# Altium schematic sheet [07] USB_HUB.SchDoc(BLK4)
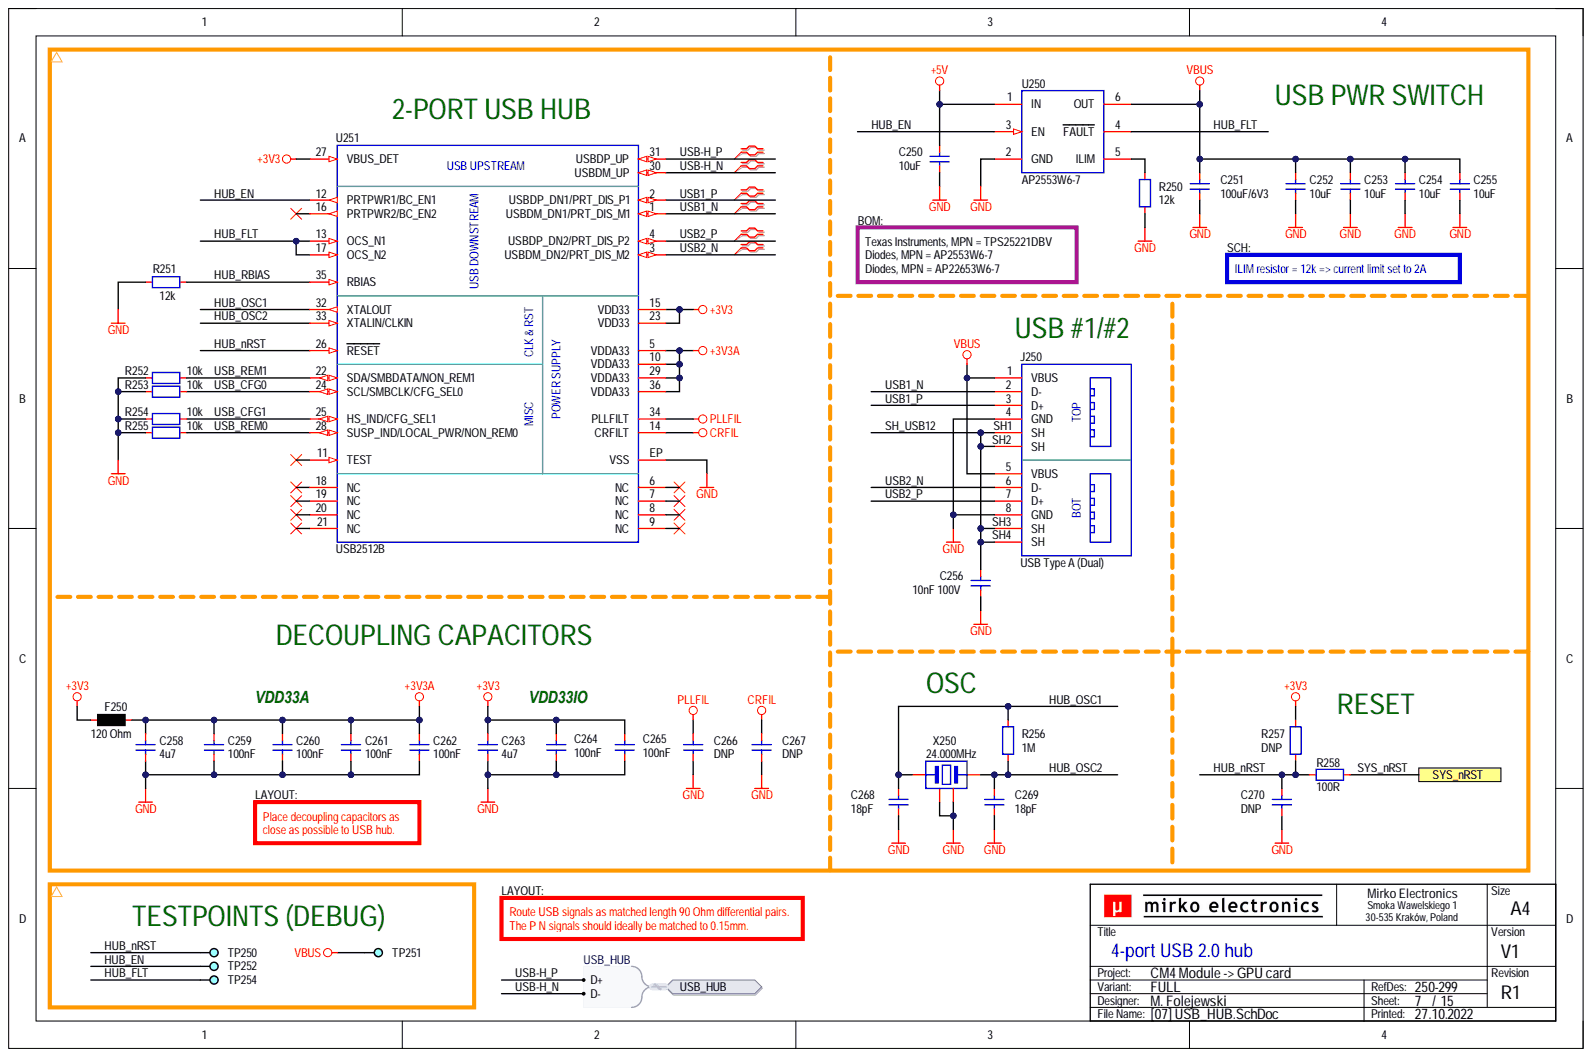
[diagram p0: [07] USB_HUB.SchDoc]

## Parts
C250  10uF  [CAP_0603_R]
C251  100uF/6V3  [CAP_1206_R]
C252  10uF  [CAP_0603_R]
C253  10uF  [CAP_0603_R]
C254  10uF  [CAP_0603_R]
C255  10uF  [CAP_0603_R]
C256  10nF 100V  [CAP_0603_R]
C258  4u7  [CAP_0603_R]
C259  100nF  [CAP_0603_R]
C260  100nF  [CAP_0603_R]
C261  100nF  [CAP_0603_R]
C262  100nF  [CAP_0603_R]
C263  4u7  [CAP_0603_R]
C264  100nF  [CAP_0603_R]
C265  100nF  [CAP_0603_R]
C266  DNP  [CAP_0603_R]
C267  DNP  [CAP_0603_R]
C268  18pF  [CAP_0603_R]
C269  18pF  [CAP_0603_R]
C270  DNP  [CAP_0603_R]
F250  120 Ohm  [FER_0603_R]
J250  USB Type A (Dual)  [CON_USB_TYPE_A_2X]
R250  12k  [RES_0603_R]
R251  12k  [RES_0603_R]
R252  10k  [RES_0603_R]
R253  10k  [RES_0603_R]
R254  10k  [RES_0603_R]
R255  10k  [RES_0603_R]
R256  1M  [RES_0603_R]
R257  DNP  [RES_0603_R]
R258  100R  [RES_0603_R]
TP250  DEBUG  [PCB_TP_DBG_50]  (value not drawn)  (no BOM)
TP251  DEBUG  [PCB_TP_DBG_50]  (no BOM)
TP252  DEBUG  [PCB_TP_DBG_50]  (value not drawn)  (no BOM)
TP254  DEBUG  [PCB_TP_DBG_50]  (value not drawn)  (no BOM)
U250  AP2553W6-7  [PKG_SOT23-6]
U251  USB2512B
X250  24.000MHz  [OSC_3225_4P_R]

## Ports
SYS_nRST, USB_HUB, USB_HUB.D+, USB_HUB.D-

## Nets
+3V3: C263.2 C264.2 C265.2 F250.1 R257.2 U251.15(VDD33) U251.23(VDD33) U251.27(VBUS_DET)
+3V3A: C258.2 C259.2 C260.2 C261.2 C262.2 F250.2 U251.5(VDDA33) U251.10(VDDA33) U251.29(VDDA33) U251.36(VDDA33)
+5V: C250.2 U250.1(IN)
CRFIL: C267.2 U251.14(CRFILT)
GND: C250.1 C251.1 C252.1 C253.1 C254.1 C255.1 C256.1 C258.1 C259.1 C260.1 C261.1 C262.1 C263.1 C264.1 C265.1 C266.1 C267.1 C268.1 C269.1 C270.1 J250.4(GND) J250.8(GND) R250.1 R251.1 R252.1 R253.1 R254.1 R255.1 U250.2(GND) U251.EP(VSS) X250.2 X250.4
PLLFIL: C266.2 U251.34(PLLFILT)
VBUS: C251.2 C252.2 C253.2 C254.2 C255.2 J250.1(VBUS) J250.5(VBUS) TP251.1 U250.6(OUT)
HUB_EN: TP252.1 U250.3(EN) U251.12(PRTPWR1/BC_EN1)
HUB_FLT: TP254.1 U250.4(FAULT) U251.13(OCS_N1) U251.17(OCS_N2)
HUB_nRST: C270.2 R257.1 R258.1 TP250.1 U251.26(RESET)
HUB_OSC1: C268.2 R256.2 U251.32(XTALOUT) X250.1
HUB_OSC2: C269.2 R256.1 U251.33(XTALIN/CLKIN) X250.3
HUB_RBIAS: R251.2 U251.35(RBIAS)
SH_USB12: C256.2 J250.SH1(SH) J250.SH2(SH) J250.SH3(SH) J250.SH4(SH)
SYS_nRST: R258.2 ; port SYS_nRST
USB1_N: J250.2(D-) U251.1(USBDM_DN1/PRT_DIS_M1)
USB1_P: J250.3(D+) U251.2(USBDP_DN1/PRT_DIS_P1)
USB2_N: J250.6(D-) U251.3(USBDM_DN2/PRT_DIS_M2)
USB2_P: J250.7(D+) U251.4(USBDP_DN2/PRT_DIS_P2)
USB-H_N: U251.30(USBDM_UP) ; port USB_HUB
USB-H_P: U251.31(USBDP_UP) ; port USB_HUB
USB_CFG0: R253.2 U251.24(SCL/SMBCLK/CFG_SEL0)
USB_CFG1: R254.2 U251.25(HS_IND/CFG_SEL1)
USB_REM0: R255.2 U251.28(SUSP_IND/LOCAL_PWR/NON_REM0)
USB_REM1: R252.2 U251.22(SDA/SMBDATA/NON_REM1)
NetR250_2: R250.2 U250.5(ILIM)

Not connected: U251 6(NC) 7(NC) 8(NC) 9(NC) 11(TEST) 16(PRTPWR2/BC_EN2) 18(NC) 19(NC) 20(NC) 21(NC)

## Library data of the parts
C250 C252-C256 C258 C263 = CAP-0023: Capacitor; MLCC; GENERIC; TBD; TBD; TBD; TBD; SMD; 0603 (1608);; Database Table Name: CAPACITOR$; Component Kind: Standard; Component Subtype: MLCC; Component Type: Capacitor; Manufacturer: GENERIC; Mounting Type: SMD; MPN: GENERIC; Package (Case): 0603 (1608); Supplier: ?; Library Name: MirFol_db_local.DbLib
  C250 C252-C255: Value: 10uF
  C256: Value: 10nF 100V
  C258 C263: Value: 4u7
C251 = CAP-0025: Capacitor; MLCC; GENERIC; TBD; TBD; TBD; TBD; SMD; 1206 (3216);; Database Table Name: CAPACITOR$; Component Kind: Standard; Component Subtype: MLCC; Component Type: Capacitor; Manufacturer: GENERIC; Mounting Type: SMD; MPN: GENERIC; Package (Case): 1206 (3216); Supplier: ?; Value: 100uF/6V3; Library Name: MirFol_db_local.DbLib
C259-C262 C264 C265 = CAP-0008: Capacitor; MLCC; 100nF; TBD; TBD; TBD; TBD; SMD; 0603 (1608);; Database Table Name: CAPACITOR$; Component Kind: Standard; Component Subtype: MLCC; Component Type: Capacitor; Manufacturer: TBD; Mounting Type: SMD; MPN: TBD; Package (Case): 0603 (1608); Supplier: ?; Value: 100nF; Library Name: MirFol_db_local.DbLib
C266 C267 C270 = CAP-0018: Capacitor; MLCC; DNP; TBD; TBD; TBD; DO NOT PLACE; SMD; 0603 (1608);; Database Table Name: CAPACITOR$; Component Kind: Standard; Component Subtype: MLCC; Component Type: Capacitor; Manufacturer: DNP; Mounting Type: SMD; MPN: DNP; Package (Case): 0603 (1608); Supplier: ?; Value: DNP; Library Name: MirFol_db_local.DbLib
C268 C269 = CAP-0020: Capacitor; MLCC; 18pF; TBD; TBD; TBD; TBD; SMD; 0603 (1608);; Database Table Name: CAPACITOR$; Component Kind: Standard; Component Subtype: MLCC; Component Type: Capacitor; Manufacturer: TBD; Mounting Type: SMD; MPN: TBD; Package (Case): 0603 (1608); Supplier: ?; Value: 18pF; Library Name: MirFol_db_local.DbLib
F250 = FIL-0001: Inductor; Ferrite Bead; 120 Ohm; 2000mA; TBD; TBD; TBD; SMD; 0603 (1608);; Database Table Name: FILTER (EMI_EMC)$; Component Kind: Standard; Component Subtype: Ferrite Bead; Component Type: Inductor; Manufacturer: TBD; Mounting Type: SMD; MPN: TBD; Package (Case): 0603 (1608); Supplier: ?; Value: 120 Ohm; Library Name: MirFol_db_local.DbLib
J250 = CON-0009: Connector; USB Type A (Dual); 2 x 4 pins; TBD; TBD; TBD; TBD; THT; THT;; Database Table Name: CONNECTOR$; Component Kind: Standard; Component Subtype: USB Type A (Dual); Component Type: Connector; Manufacturer: TBD; Mounting Type: THT; MPN: TBD; Package (Case): THT; Supplier: ?; Value: USB Type A (Dual); Library Name: MirFol_db_local.DbLib
R250 = RES-0054: Resistor; Thick Film; GENERIC; TBD; TBD; TBD; TBD; TBD; SMD; 0603 (1608);; Database Table Name: RESISTOR$; Component Kind: Standard; Component Subtype: Thick Film; Component Type: Resistor; Manufacturer: GENERIC; Mounting Type: SMD; MPN: GENERIC; Package (Case): 0603 (1608); Supplier: ?; Value: 12k; Library Name: MirFol_db_local.DbLib
R251 = RES-0053: Resistor; Thick Film; 12k; 1%; 100mW; 100PPM; 75V; Standard; SMD; 0603 (1608);; Manufacturer: Yageo Corporation; MPN: TBD; Database Table Name: RESISTOR$; Component Kind: Standard; Component Subtype: Thick Film; Component Type: Resistor; Mounting Type: SMD; Package (Case): 0603 (1608); Supplier: ?; Value: 12k; Library Name: MirFol_db_local.DbLib
R252-R255 = RES-0028: Resistor; Thick Film; 10k; 1%; 100mW; 100PPM; 75V; Standard; SMD; 0603 (1608);; Manufacturer: Yageo Corporation; MPN: RC0603FR-0710KL; Database Table Name: RESISTOR$; Component Kind: Standard; Component Subtype: Thick Film; Component Type: Resistor; Mounting Type: SMD; Package (Case): 0603 (1608); Supplier: ?; Value: 10k; Library Name: MirFol_db_local.DbLib
R256 = RES-0050: Resistor; Thick Film; 1M; 1%; 100mW; 100PPM; 75V; Standard; SMD; 0603 (1608);; Manufacturer: Yageo Corporation; MPN: TBD; Database Table Name: RESISTOR$; Component Kind: Standard; Component Subtype: Thick Film; Component Type: Resistor; Mounting Type: SMD; Package (Case): 0603 (1608); Supplier: ?; Value: 1M; Library Name: MirFol_db_local.DbLib
R257 = RES-0051: Resistor; Thick Film; DNP; TBD; TBD; TBD; TBD; DO NOT PLACE; SMD; 0603 (1608);; Database Table Name: RESISTOR$; Component Kind: Standard; Component Subtype: Thick Film; Component Type: Resistor; Manufacturer: TBD; Mounting Type: SMD; MPN: TBD; Package (Case): 0603 (1608); Supplier: ?; Value: DNP; Library Name: MirFol_db_local.DbLib
R258 = RES-0026: Resistor; Thick Film; 100R; 1%; 100mW; 100PPM; 75V; Standard; SMD; 0603 (1608);; Manufacturer: Yageo Corporation; MPN: TBD; Database Table Name: RESISTOR$; Component Kind: Standard; Component Subtype: Thick Film; Component Type: Resistor; Mounting Type: SMD; Package (Case): 0603 (1608); Supplier: ?; Value: 100R; Library Name: MirFol_db_local.DbLib
TP250-TP252 TP254 = PCB_TP_DBG: PCB Marking; Test Point (Debug);; Component Subtype: -; Component Type: Testpoint; Mounting Type: -; Supplier: ?; Value: DEBUG; Library Name: MirFol_lib.SchLib
U250 = ICC_TXI_TPS25221_DBV: IC; Current Limited Switch;; Manufacturer: Diodes Incorporated; Package (Case): SOT26; Component Kind: Standard; Component Subtype: Current Limited Switch; Component Type: IC; Mounting Type: SMD; MPN: AP2553W6-7; Supplier: ?; Value: AP2553W6-7; Library Name: MirFol_lib.SchLib
U251 = ICC_MCP_USB2512B_QFN36: IC; USB Hub;; Manufacturer: Microchip Technology; Component Subtype: USB Hub; Component Kind: Standard; Component Type: IC; Mounting Type: SMD; MPN: USB2512B; Supplier: ?; Value: USB2512B; Library Name: MirFol_lib.SchLib
X250 = OSC_XTL_P4: Oscillator; Crystal;; Component Kind: Standard; Component Subtype: Crystal; Component Type: Crystal; Mounting Type: SMD; Supplier: ?; Value: 24.000MHz; Library Name: MirFol_lib.SchLib
